# Altium schematic sheet 200_Actuator.SchDoc
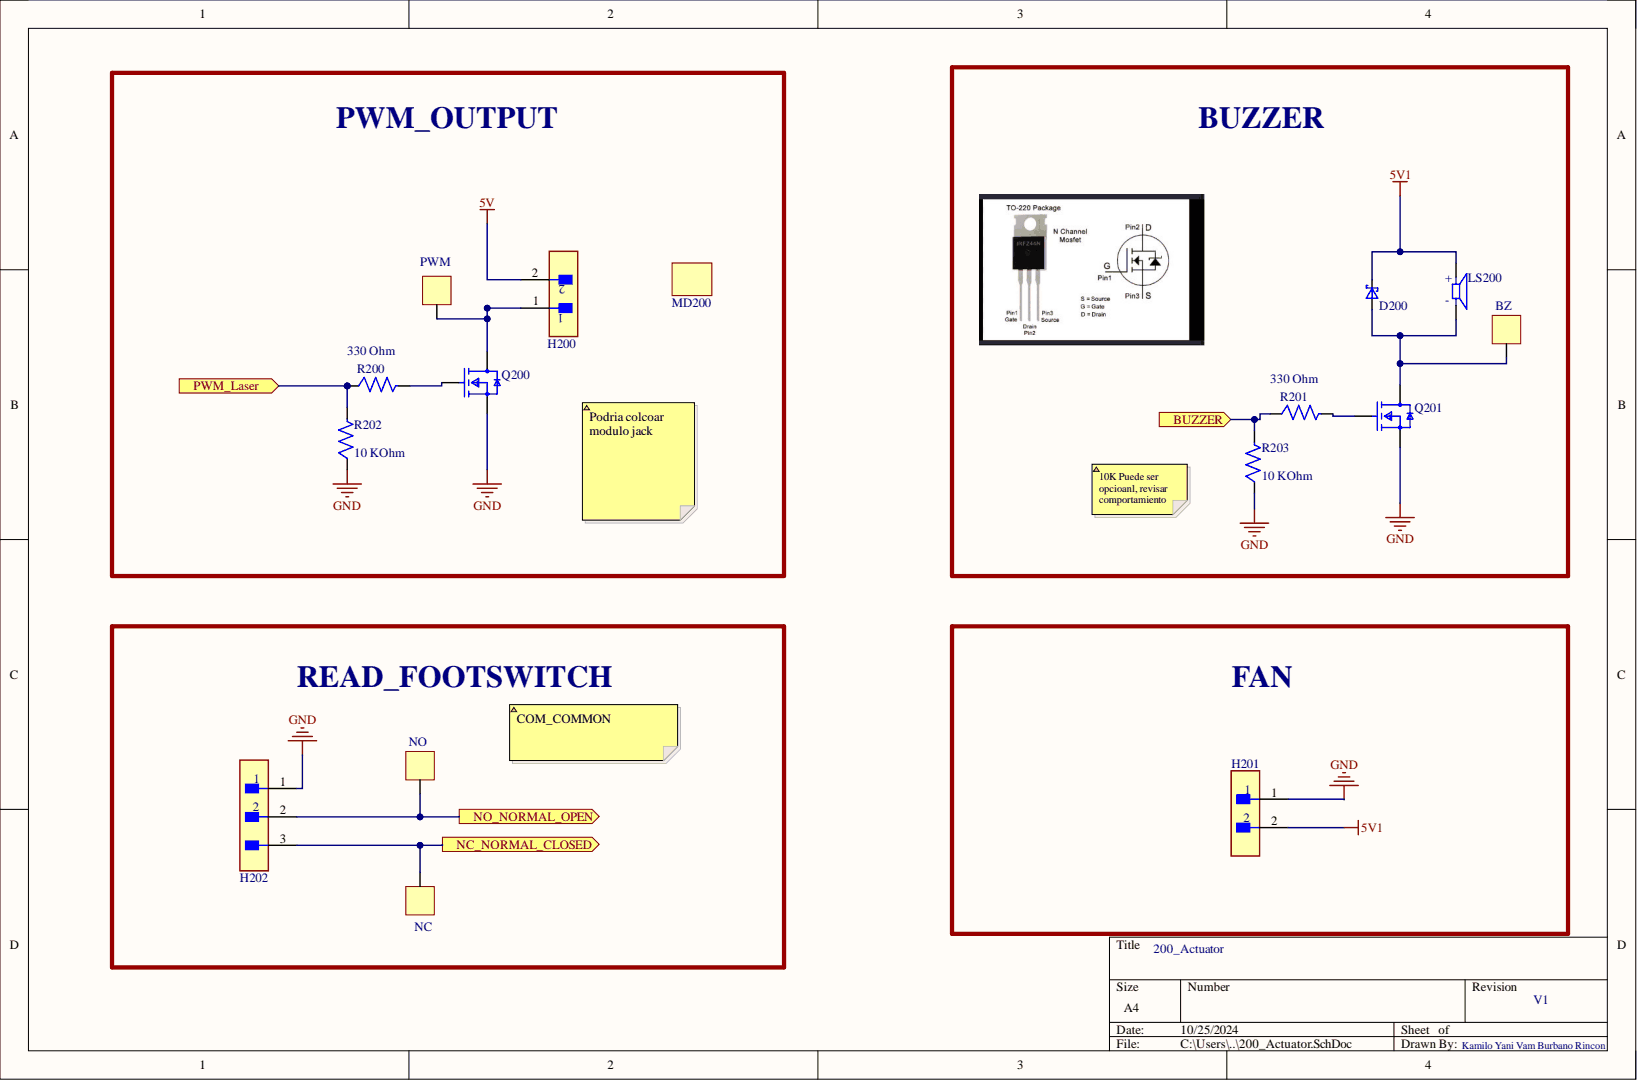
[diagram p0: 200_Actuator.SchDoc]

## Parts
BZ  TP_SMT_30mil  [TP_SMT_30mil]  (value not drawn)
D200  D_Schottky_40V_1A  [THT_5mmx5mm]  (value not drawn)
H200  H_2x1_THT_2.54mm_Header  [H_2x1_THT_2.54mm]  (value not drawn)
H201  H_2x1_THT_2.54mm_Header  [H_2x1_THT_2.54mm]  (value not drawn)
H202  H_3x1_THT_2.54mm_Header  [H_3x1_THT_2.54mm]  (value not drawn)
LS200  Bzr_12mm_2.4KHz_THT_Magnetic  [BZR_BZ-002]  (value not drawn)
MD200  Mod_JACK_MALE  (value not drawn)
NC  TP_SMT_30mil  [TP_SMT_30mil]  (value not drawn)
NO  TP_SMT_30mil  [TP_SMT_30mil]  (value not drawn)
PWM  TP_SMT_30mil  [TP_SMT_30mil]  (value not drawn)
Q200  Q_NFET_55V_49A_TO220AB  [TO220AB]  (value not drawn)
Q201  Q_NFET_55V_49A_TO220AB  [TO220AB]  (value not drawn)
R200  value 330 Ohm  [Axial]  (hidden comment: 330 Ohm 1/4W)
R201  value 330 Ohm  [Axial]  (hidden comment: 330 Ohm 1/4W)
R202  value 10 KOhm  [Axial]  (hidden comment: 10 KOhm 1/4W)
R203  value 10 KOhm  [Axial]  (hidden comment: 10 KOhm 1/4W)

## Ports
BUZZER, NC_NORMAL_CLOSED, NO_NORMAL_OPEN, PWM_Laser

## Nets
5V: H200.2
5V1: D200.1 H201.2 LS200.P
GND: H201.1 H202.1 Q200.3 Q201.3 R202.1 R203.1
BUZZER: R201.1 R203.2 ; port BUZZER
NC_NORMAL_CLOSED: H202.3 NC.1 ; port NC_NORMAL_CLOSED
NO_NORMAL_OPEN: H202.2 NO.1 ; port NO_NORMAL_OPEN
PWM_Laser: R200.1 R202.2 ; port PWM_Laser
NetBZ_1: BZ.1 D200.2 LS200.N Q201.2
NetH200_1: H200.1 PWM.1 Q200.2
NetQ200_1: Q200.1 R200.2
NetQ201_1: Q201.1 R201.2

## Library data of the parts
BZ NC NO PWM = TP_SMT_30mil: Test point 30mil hole size; Component Kind: Standard; ComponentLink1Description: Digi-Key; Last modified date: 20231205; Supplier 1: Digi-key; Last modified by: K-Y.Burbano; Library Name: Library_Kam_ilo.IntLib
D200 = D_Schottky_40V_1A: DIODE SCHOTTKY 40V 1A; Component Kind: Standard; ComponentLink1Description: Vistronica; ComponentLink1URL: https://www.vistronica.com/componentes-activos/diodos/diodo-rectificador-schottky-1n5819-detail.html; Last modified date: 2024059; Supplier 1: Vistronica; Last modified by: K-Y.Burbano; Library Name: Library_Kam_ilo.IntLib
H200 H201 = H_2x1_THT_2.54mm_Header: CONN HEADER THT 2POS 2.54MM; Component Kind: Standard; ComponentLink1Description: Digi-Key; ComponentLink1URL: https://www.digikey.com/en/products/detail/samtec-inc/TSM-102-01-L-SV-P-TR/9593647; Last modified date: 2024058; Last modified by: K-Y.Burbano; Library Name: Library_Kam_ilo.IntLib
H202 = H_3x1_THT_2.54mm_Header: CONN HEADER THT 3POS 2.54MM; Component Kind: Standard; ComponentLink1Description: Electronica i+d; ComponentLink1URL: https://www.didacticaselectronicas.com/index.php/suiches-y-conectores/headers/HD40P-M-R-detail; Last modified date: 20240511; Last modified by: K-Y.Burbano; Library Name: Library_Kam_ilo.IntLib
LS200 = Bzr_12mm_2.4KHz_THT_Magnetic: • 3-15 Vdc rated  • 85 dB  • through hole  • magnetic  • internally driven  • narrow frequency range  • used in medical & security applications; Component Kind: Standard; ComponentLink1Description: Electronica i+d; ComponentLink1URL: https://www.didacticaselectronicas.com/index.php/audio-y-video/buzzer/bz-002-detail; Last modified date: 20240510; Supplier 1: Electronica i+d; Last modified by: K-Y.Burbano; Library Name: Library_Kam_ilo.IntLib
MD200 = Mod_JACK_MALE: CONECTOR JACK; Component Kind: Standard; ComponentLink1Description: ELECTRONICA I+D; ComponentLink1URL: https://www.didacticaselectronicas.com/index.php/suiches-y-conectores/borneras/BORNERA-JACK-MACHO-detail; Last modified date: 20240511; Supplier 1: ELECTRONICA I+D; Last modified by: K-Y.Burbano; Library Name: Library_Kam_ilo.IntLib
Q200 Q201 = Q_NFET_55V_49A_TO220AB: MOSFET N-CH 55V 49A TO220AB.; Component Kind: Standard; ComponentLink1Description: Electronica i+d; ComponentLink1URL: https://www.didacticaselectronicas.com/index.php/semiconductores/transistores-y-mosfet/mosfet/IRFZ44-detail; Last modified date: 2024058; Supplier 1: Electronica i+d; Last modified by: K-Y.Burbano; Library Name: Library_Kam_ilo.IntLib
R200 R201 = Res_330Ohm_THT_1/4W: RES 330 OHM 1% 1/4W THT; Component Kind: Standard; ComponentLink1Description: VISTRONICA; ComponentLink1URL: https://www.vistronica.com/componentes-pasivos/resistencias/resistencias-330-ohm-metal-film-axial-14w-1-x10-detail.html; Last modified date: 2024058; Supplier 1: VISTRONICA; Last modified by: K-Y.Burbano; Library Name: Library_Kam_ilo.IntLib
R202 R203 = Res_10KOhm_TH_1/4W: 10 kOhms ±1% 0.25W, 1/4W Through Hole Resistor Axial Metal Film; Component Kind: Standard; ComponentLink1Description: Digi-Key; ComponentLink1URL: https://www.didacticaselectronicas.com/index.php/componentes-pasivos/resistencias/through-hole/rp-10k-1-4w-detail; Last modified date: 2024055; Supplier 1: Electronica i+d; Last modified by: K-Y.Burbano; Library Name: Library_Kam_ilo.IntLib
